# Altium schematic sheet PWR_Manage.SchDoc
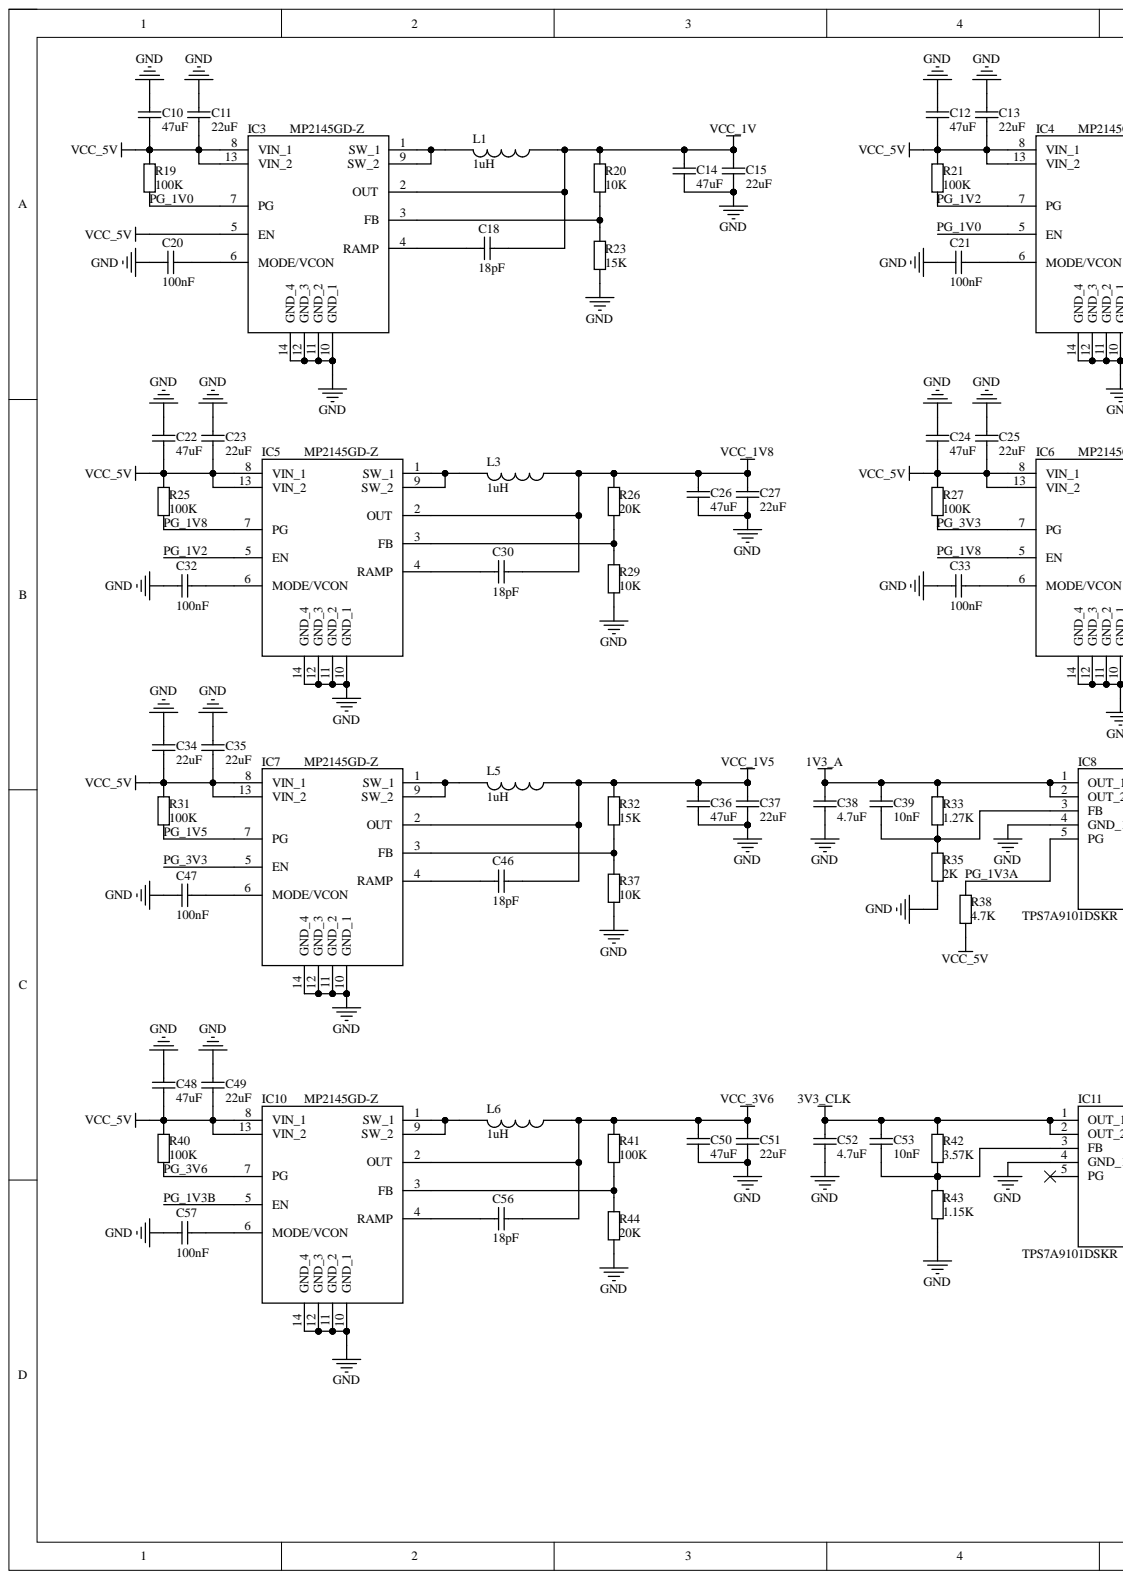
[diagram p0: PWR_Manage.SchDoc, left]
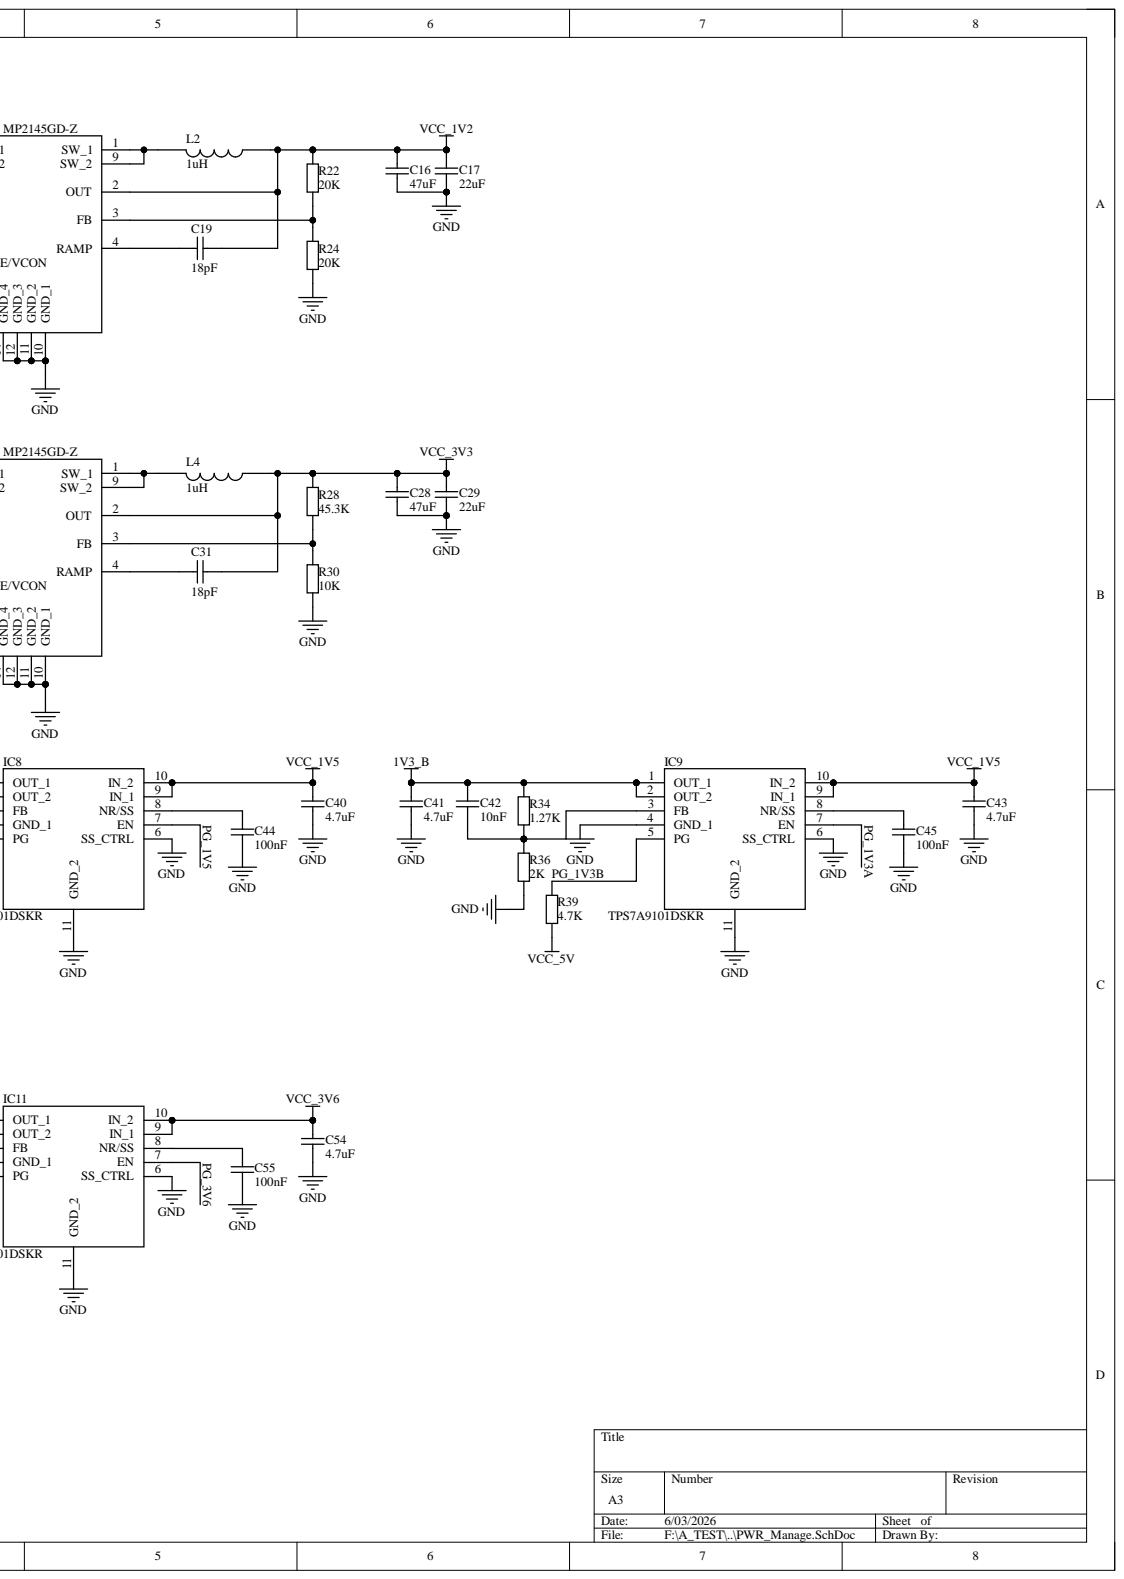
[diagram p1: PWR_Manage.SchDoc, right]

## Parts
C10  47uF  [C 0805_L]
C11  22uF  [C 0805_L]
C12  47uF  [C 0805_L]
C13  22uF  [C 0805_L]
C14  47uF  [C 0805_L]
C15  22uF  [C 0805_L]
C16  47uF  [C 0805_L]
C17  22uF  [C 0805_L]
C18  18pF  [C 0402_L]
C19  18pF  [C 0402_L]
C20  100nF  [C 0402_L]
C21  100nF  [C 0402_L]
C22  47uF  [C 0805_L]
C23  22uF  [C 0805_L]
C24  47uF  [C 0805_L]
C25  22uF  [C 0805_L]
C26  47uF  [C 0805_L]
C27  22uF  [C 0805_L]
C28  47uF  [C 0805_L]
C29  22uF  [C 0805_L]
C30  18pF  [C 0402_L]
C31  18pF  [C 0402_L]
C32  100nF  [C 0402_L]
C33  100nF  [C 0402_L]
C34  22uF  [C 0805_L]
C35  22uF  [C 0805_L]
C36  47uF  [C 0805_L]
C37  22uF  [C 0805_L]
C38  4.7uF  [C 0402_L]
C39  10nF  [C 0402_L]
C40  4.7uF  [C 0402_L]
C41  4.7uF  [C 0402_L]
C42  10nF  [C 0402_L]
C43  4.7uF  [C 0402_L]
C44  100nF  [C 0402_L]
C45  100nF  [C 0402_L]
C46  18pF  [C 0402_L]
C47  100nF  [C 0402_L]
C48  47uF  [C 0805_L]
C49  22uF  [C 0805_L]
C50  47uF  [C 0805_L]
C51  22uF  [C 0805_L]
C52  4.7uF  [C 0402_L]
C53  10nF  [C 0402_L]
C54  4.7uF  [C 0402_L]
C55  100nF  [C 0402_L]
C56  18pF  [C 0402_L]
C57  100nF  [C 0402_L]
IC3  MP2145GD-Z  [MP2145GDZ]
IC4  MP2145GD-Z  [MP2145GDZ]
IC5  MP2145GD-Z  [MP2145GDZ]
IC6  MP2145GD-Z  [MP2145GDZ]
IC7  MP2145GD-Z  [MP2145GDZ]
IC8  TPS7A9101DSKR  [SON50P250X250X80-11N-D]
IC9  TPS7A9101DSKR  [SON50P250X250X80-11N-D]
IC10  MP2145GD-Z  [MP2145GDZ]
IC11  TPS7A9101DSKR  [SON50P250X250X80-11N-D]
L1  1uH  [NR2520]
L2  1uH  [NR2520]
L3  1uH  [NR2520]
L4  1uH  [NR2520]
L5  1uH  [NR2520]
L6  1uH  [NR2520]
R19  100K  [R 0402_L]
R20  10K  [R 0402_L]
R21  100K  [R 0402_L]
R22  20K  [R 0402_L]
R23  15K  [R 0402_L]
R24  20K  [R 0402_L]
R25  100K  [R 0402_L]
R26  20K  [R 0402_L]
R27  100K  [R 0402_L]
R28  45.3K  [R 0402_L]
R29  10K  [R 0402_L]
R30  10K  [R 0402_L]
R31  100K  [R 0402_L]
R32  15K  [R 0402_L]
R33  1.27K  [R 0402_L]
R34  1.27K  [R 0402_L]
R35  2K  [R 0402_L]
R36  2K  [R 0402_L]
R37  10K  [R 0402_L]
R38  4.7K  [R 0402_L]
R39  4.7K  [R 0402_L]
R40  100K  [R 0402_L]
R41  100K  [R 0402_L]
R42  3.57K  [R 0402_L]
R43  1.15K  [R 0402_L]
R44  20K  [R 0402_L]

## Nets
1V3_A: C38.2 C39.2 IC8.1(OUT_1) IC8.2(OUT_2) R33.2
1V3_B: C41.2 C42.2 IC9.1(OUT_1) IC9.2(OUT_2) R34.2
3V3_CLK: C52.2 C53.2 IC11.1(OUT_1) IC11.2(OUT_2) R42.2
GND: C10.2 C11.2 C12.2 C13.2 C14.2 C15.2 C16.2 C17.2 C20.1 C21.1 C22.2 C23.2 C24.2 C25.2 C26.2 C27.2 C28.2 C29.2 C32.1 C33.1 C34.2 C35.2 C36.2 C37.2 C38.1 C40.1 C41.1 C43.1 C44.1 C45.1 C47.1 C48.2 C49.2 C50.2 C51.2 C52.1 C54.1 C55.1 C57.1 IC3.10(GND_1) IC3.11(GND_2) IC3.12(GND_3) IC3.14(GND_4) IC4.10(GND_1) IC4.11(GND_2) IC4.12(GND_3) IC4.14(GND_4) IC5.10(GND_1) IC5.11(GND_2) IC5.12(GND_3) IC5.14(GND_4) IC6.10(GND_1) IC6.11(GND_2) IC6.12(GND_3) IC6.14(GND_4) IC7.10(GND_1) IC7.11(GND_2) IC7.12(GND_3) IC7.14(GND_4) IC8.4(GND_1) IC8.6(SS_CTRL) IC8.11(GND_2) IC9.4(GND_1) IC9.6(SS_CTRL) IC9.11(GND_2) IC10.10(GND_1) IC10.11(GND_2) IC10.12(GND_3) IC10.14(GND_4) IC11.4(GND_1) IC11.6(SS_CTRL) IC11.11(GND_2) R23.2 R24.2 R29.2 R30.2 R35.1 R36.1 R37.2 R43.1 R44.2
VCC_1V: C14.1 C15.1 C18.2 IC3.2(OUT) L1.1 R20.2
VCC_1V2: C16.1 C17.1 C19.2 IC4.2(OUT) L2.1 R22.2
VCC_1V5: C36.1 C37.1 C40.2 C43.2 C46.2 IC7.2(OUT) IC8.9(IN_1) IC8.10(IN_2) IC9.9(IN_1) IC9.10(IN_2) L5.1 R32.2
VCC_1V8: C26.1 C27.1 C30.2 IC5.2(OUT) L3.1 R26.2
VCC_3V3: C28.1 C29.1 C31.2 IC6.2(OUT) L4.1 R28.2
VCC_3V6: C50.1 C51.1 C54.2 C56.2 IC10.2(OUT) IC11.9(IN_1) IC11.10(IN_2) L6.1 R41.2
VCC_5V: C10.1 C11.1 C12.1 C13.1 C22.1 C23.1 C24.1 C25.1 C34.1 C35.1 C48.1 C49.1 IC3.5(EN) IC3.8(VIN_1) IC3.13(VIN_2) IC4.8(VIN_1) IC4.13(VIN_2) IC5.8(VIN_1) IC5.13(VIN_2) IC6.8(VIN_1) IC6.13(VIN_2) IC7.8(VIN_1) IC7.13(VIN_2) IC10.8(VIN_1) IC10.13(VIN_2) R19.2 R21.2 R25.2 R27.2 R31.2 R38.1 R39.1 R40.2
PG_1V0: IC3.7(PG) IC4.5(EN) R19.1
PG_1V2: IC4.7(PG) IC5.5(EN) R21.1
PG_1V3A: IC8.5(PG) IC9.7(EN) R38.2
PG_1V3B: IC9.5(PG) IC10.5(EN) R39.2
PG_1V5: IC7.7(PG) IC8.7(EN) R31.1
PG_1V8: IC5.7(PG) IC6.5(EN) R25.1
PG_3V3: IC6.7(PG) IC7.5(EN) R27.1
PG_3V6: IC10.7(PG) IC11.7(EN) R40.1
NetC18_1: C18.1 IC3.4(RAMP)
NetC19_1: C19.1 IC4.4(RAMP)
NetC20_2: C20.2 IC3.6(MODE/VCON)
NetC21_2: C21.2 IC4.6(MODE/VCON)
NetC30_1: C30.1 IC5.4(RAMP)
NetC31_1: C31.1 IC6.4(RAMP)
NetC32_2: C32.2 IC5.6(MODE/VCON)
NetC33_2: C33.2 IC6.6(MODE/VCON)
NetC39_1: C39.1 IC8.3(FB) R33.1 R35.2
NetC42_1: C42.1 IC9.3(FB) R34.1 R36.2
NetC44_2: C44.2 IC8.8(NR/SS)
NetC45_2: C45.2 IC9.8(NR/SS)
NetC46_1: C46.1 IC7.4(RAMP)
NetC47_2: C47.2 IC7.6(MODE/VCON)
NetC53_1: C53.1 IC11.3(FB) R42.1 R43.2
NetC55_2: C55.2 IC11.8(NR/SS)
NetC56_1: C56.1 IC10.4(RAMP)
NetC57_2: C57.2 IC10.6(MODE/VCON)
NetIC3_1: IC3.1(SW_1) IC3.9(SW_2) L1.2
NetIC3_3: IC3.3(FB) R20.1 R23.1
NetIC4_1: IC4.1(SW_1) IC4.9(SW_2) L2.2
NetIC4_3: IC4.3(FB) R22.1 R24.1
NetIC5_1: IC5.1(SW_1) IC5.9(SW_2) L3.2
NetIC5_3: IC5.3(FB) R26.1 R29.1
NetIC6_1: IC6.1(SW_1) IC6.9(SW_2) L4.2
NetIC6_3: IC6.3(FB) R28.1 R30.1
NetIC7_1: IC7.1(SW_1) IC7.9(SW_2) L5.2
NetIC7_3: IC7.3(FB) R32.1 R37.1
NetIC10_1: IC10.1(SW_1) IC10.9(SW_2) L6.2
NetIC10_3: IC10.3(FB) R41.1 R44.1

Not connected: IC11 5(PG)

## Library data of the parts
C10-C57 = Cap: 贴片电容; Component Kind: Standard; Library Name: A_Schlib.SchLib
  C10 C12 C14 C16 C22 C24 C26 C28 C36 C48 C50: value: 47uF
  C11 C13 C15 C17 C23 C25 C27 C29 C34 C35 C37 C49 C51: value: 22uF
  C18 C19 C30 C31 C46 C56: value: 18pF
  C20 C21 C32 C33 C44 C45 C47 C55 C57: value: 100nF
  C38 C40 C41 C43 C52 C54: value: 4.7uF
  C39 C42 C53: value: 10nF
IC3-IC7 IC10 = MP2145GD-Z: Integrated Circuit; Manufacturer_Name: Monolithic Power Systems (MPS); Component Kind: Standard; Datasheet Link: https://www.monolithicpower.com/en/documentview/productdocument/index/version/2/document_type/Datasheet/lang/en/sku/MP2145/document_id/832/; Height: 1mm; Library Name: SamacSys.SchLib; Manufacturer_Part_Number: MP2145GD-Z; Mouser Part Number: 946-MP2145GD-Z; Mouser Price/Stock: https://www.mouser.co.uk/ProductDetail/Monolithic-Power-Systems-MPS/MP2145GD-Z?qs=AfGmtpoX2giMfxnoRRoQSw%3D%3D
IC8 IC9 IC11 = TPS7A9101DSKR: Integrated Circuit; Manufacturer_Name: Texas Instruments; Arrow Part Number: TPS7A9101DSKR; Arrow Price/Stock: https://www.arrow.com/en/products/tps7a9101dskr/texas-instruments?region=nac; Component Kind: Standard; Datasheet Link: http://www.ti.com/lit/ds/symlink/tps7a91.pdf; Height: 0.8mm; Manufacturer_Part_Number: TPS7A9101DSKR; Mouser Part Number: 595-TPS7A9101DSKR; Mouser Price/Stock: https://www.mouser.co.uk/ProductDetail/Texas-Instruments/TPS7A9101DSKR?qs=34RfhUjJmKdov7HQNWp7rg%3D%3D
L1-L6 = 电感: 包含功率; Component Kind: Standard; Library Name: A_Schlib.SchLib
R19-R44 = Res: 通用; Component Kind: Standard; Library Name: A_Schlib.SchLib
  R19 R21 R25 R27 R31 R40 R41: value: 100K
  R20 R29 R30 R37: value: 10K
  R22 R24 R26 R44: value: 20K
  R23 R32: value: 15K
  R28: value: 45.3K
  R33 R34: value: 1.27K
  R35 R36: value: 2K
  R38 R39: value: 4.7K
  R42: value: 3.57K
  R43: value: 1.15K
